# Altium schematic sheet NTC Sensors.SchDoc("NTC Sensors")
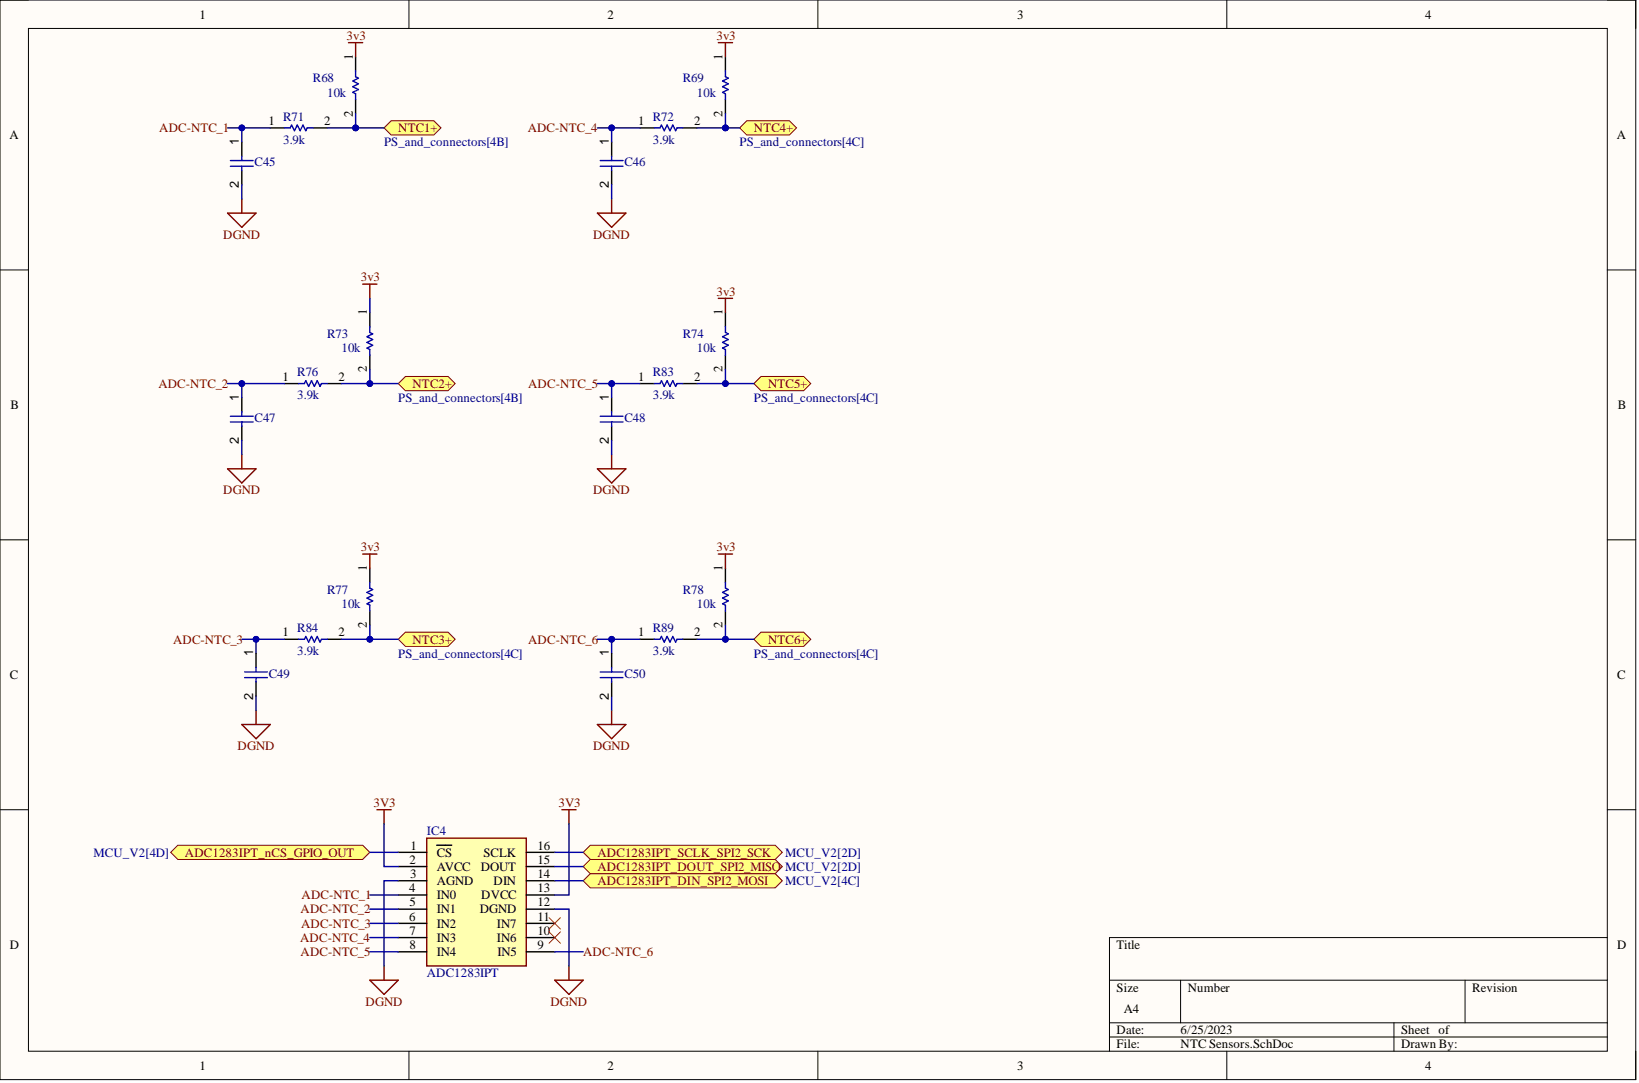
[diagram p0: NTC Sensors.SchDoc]

## Parts
C45  (no value)
C46  (no value)
C47  (no value)
C48  (no value)
C49  (no value)
C50  (no value)
IC4  ADC1283IPT
R68  10k
R69  10k
R71  3.9k
R72  3.9k
R73  10k
R74  10k
R76  3.9k
R77  10k
R78  10k
R83  3.9k
R84  3.9k
R89  3.9k

## Ports
ADC1283IPT_DIN_SPI2_MOSI, ADC1283IPT_DOUT_SPI2_MISO, ADC1283IPT_nCS_GPIO_OUT, ADC1283IPT_SCLK_SPI2_SCK, NTC1+, NTC2+, NTC3+, NTC4+, NTC5+, NTC6+

## Nets
3v3: IC4.2(AVCC) IC4.13(DVCC) R68.1 R69.1 R73.1 R74.1 R77.1 R78.1
DGND: C45.2 C46.2 C47.2 C48.2 C49.2 C50.2 IC4.3(AGND) IC4.12(DGND)
ADC1283IPT_DIN_SPI2_MOSI: IC4.14(DIN) ; port ADC1283IPT_DIN_SPI2_MOSI
ADC1283IPT_DOUT_SPI2_MISO: IC4.15(DOUT) ; port ADC1283IPT_DOUT_SPI2_MISO
ADC1283IPT_nCS_GPIO_OUT: IC4.1(CS) ; port ADC1283IPT_nCS_GPIO_OUT
ADC1283IPT_SCLK_SPI2_SCK: IC4.16(SCLK) ; port ADC1283IPT_SCLK_SPI2_SCK
ADC-NTC_1: C45.1 IC4.4(IN0) R71.1
ADC-NTC_2: C47.1 IC4.5(IN1) R76.1
ADC-NTC_3: C49.1 IC4.6(IN2) R84.1
ADC-NTC_4: C46.1 IC4.7(IN3) R72.1
ADC-NTC_5: C48.1 IC4.8(IN4) R83.1
ADC-NTC_6: C50.1 IC4.9(IN5) R89.1
NTC1+: R68.2 R71.2 ; port NTC1+
NTC2+: R73.2 R76.2 ; port NTC2+
NTC3+: R77.2 R84.2 ; port NTC3+
NTC4+: R69.2 R72.2 ; port NTC4+
NTC5+: R74.2 R83.2 ; port NTC5+
NTC6+: R78.2 R89.2 ; port NTC6+

Not connected: IC4 10(IN6) 11(IN7)

## Library data of the parts
C45-C50 = CMP-00009-1: VJ0805Y104MXATW1BC; Component Kind: Standard; Library Name: EMEA- Squadra Corse PoliTO-  Politecnico di Torino
IC4 = CMP-007-000007-2: 8-Channel; Manufacturer_Name: STMicroelectronics; Component Kind: Standard; Datasheet Link: https://www.st.com/resource/en/datasheet/adc1283.pdf; Design Item ID: ADC1283IPT; Height: 1.2mm; Manufacturer_Part_Number: ADC1283IPT; Source: SamacSys.SchLib; Library Name: EMEA- Squadra Corse PoliTO-  Politecnico di Torino
R68 R69 R73 R74 R77 R78 = CMP-009-00181-1: RC1206FR-0710KL; Tech: SMD; Component Kind: Standard; Package: 1206; Library Name: EMEA- Squadra Corse PoliTO-  Politecnico di Torino
R71 R72 R76 R83 R84 R89 = CMP-00012-1: CRCW08053K90FKEAHP; Component Kind: Standard; Library Name: EMEA- Squadra Corse PoliTO-  Politecnico di Torino
